# Altium schematic sheet Sheet4.SchDoc(Sheet4)
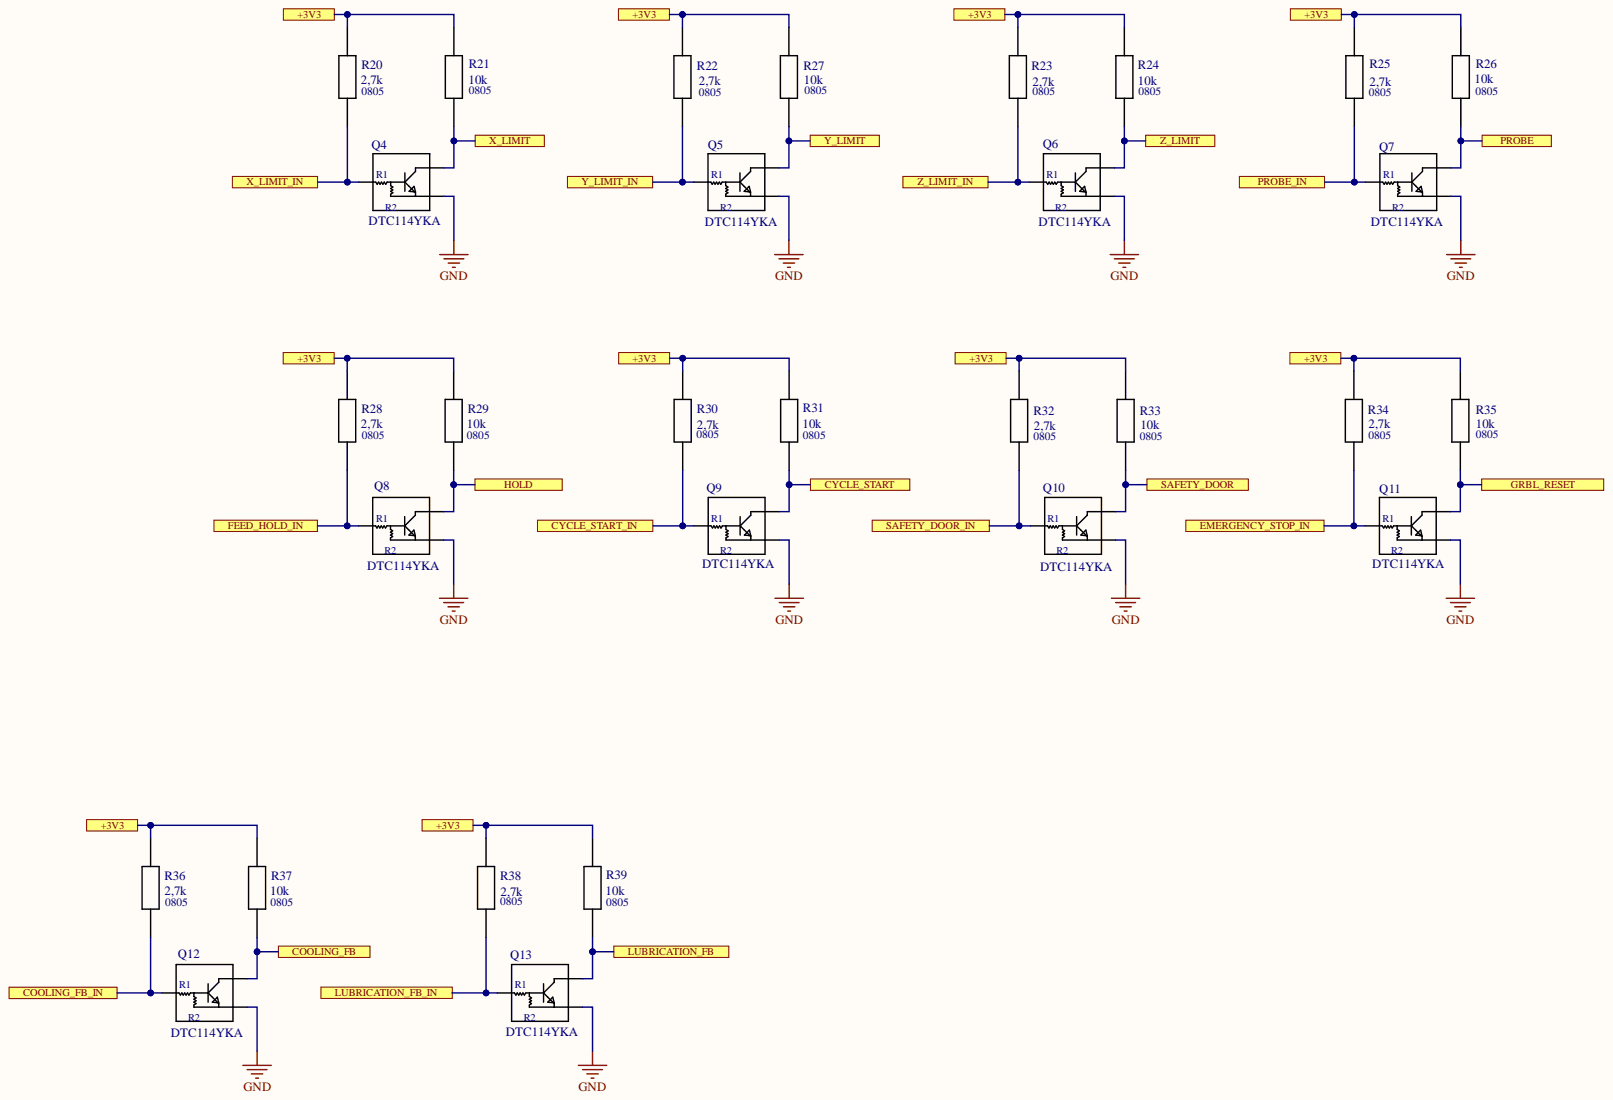
[diagram p0: Sheet4.SchDoc]

## Parts
Q4  DTC114YKA  [SOT-346 (SC59) Black]
Q5  DTC114YKA  [SOT-346 (SC59) Black]
Q6  DTC114YKA  [SOT-346 (SC59) Black]
Q7  DTC114YKA  [SOT-346 (SC59) Black]
Q8  DTC114YKA  [SOT-346 (SC59) Black]
Q9  DTC114YKA  [SOT-346 (SC59) Black]
Q10  DTC114YKA  [SOT-346 (SC59) Black]
Q11  DTC114YKA  [SOT-346 (SC59) Black]
Q12  DTC114YKA  [SOT-346 (SC59) Black]
Q13  DTC114YKA  [SOT-346 (SC59) Black]
R20  2,7k  Case: 0805  [SMD 0805]
R21  10k  Case: 0805  [SMD 0805]
R22  2,7k  Case: 0805  [SMD 0805]
R23  2,7k  Case: 0805  [SMD 0805]
R24  10k  Case: 0805  [SMD 0805]
R25  2,7k  Case: 0805  [SMD 0805]
R26  10k  Case: 0805  [SMD 0805]
R27  10k  Case: 0805  [SMD 0805]
R28  2,7k  Case: 0805  [SMD 0805]
R29  10k  Case: 0805  [SMD 0805]
R30  2,7k  Case: 0805  [SMD 0805]
R31  10k  Case: 0805  [SMD 0805]
R32  2,7k  Case: 0805  [SMD 0805]
R33  10k  Case: 0805  [SMD 0805]
R34  2,7k  Case: 0805  [SMD 0805]
R35  10k  Case: 0805  [SMD 0805]
R36  2,7k  Case: 0805  [SMD 0805]
R37  10k  Case: 0805  [SMD 0805]
R38  2,7k  Case: 0805  [SMD 0805]
R39  10k  Case: 0805  [SMD 0805]

## Ports
+3V3, COOLING_FB, COOLING_FB_IN, CYCLE_START, CYCLE_START_IN, EMERGENCY_STOP_IN, FEED_HOLD_IN, GRBL_RESET, HOLD, LUBRICATION_FB, LUBRICATION_FB_IN, PROBE, PROBE_IN, SAFETY_DOOR, SAFETY_DOOR_IN, X_LIMIT, X_LIMIT_IN, Y_LIMIT, Y_LIMIT_IN, Z_LIMIT, Z_LIMIT_IN

## Nets
GND: Q4.2 Q5.2 Q6.2 Q7.2 Q8.2 Q9.2 Q10.2 Q11.2 Q12.2 Q13.2
+3V3: R20.1 R21.1 R22.1 R23.1 R24.1 R25.1 R26.1 R27.1 R28.1 R29.1 R30.1 R31.1 R32.1 R33.1 R34.1 R35.1 R36.1 R37.1 R38.1 R39.1 ; port +3V3
COOLING_FB: Q12.3 R37.2 ; port COOLING_FB
COOLING_FB_IN: Q12.1 R36.2 ; port COOLING_FB_IN
CYCLE_START: Q9.3 R31.2 ; port CYCLE_START
CYCLE_START_IN: Q9.1 R30.2 ; port CYCLE_START_IN
EMERGENCY_STOP_IN: Q11.1 R34.2 ; port EMERGENCY_STOP_IN
FEED_HOLD_IN: Q8.1 R28.2 ; port FEED_HOLD_IN
GRBL_RESET: Q11.3 R35.2 ; port GRBL_RESET
HOLD: Q8.3 R29.2 ; port HOLD
LUBRICATION_FB: Q13.3 R39.2 ; port LUBRICATION_FB
LUBRICATION_FB_IN: Q13.1 R38.2 ; port LUBRICATION_FB_IN
PROBE: Q7.3 R26.2 ; port PROBE
PROBE_IN: Q7.1 R25.2 ; port PROBE_IN
SAFETY_DOOR: Q10.3 R33.2 ; port SAFETY_DOOR
SAFETY_DOOR_IN: Q10.1 R32.2 ; port SAFETY_DOOR_IN
X_LIMIT: Q4.3 R21.2 ; port X_LIMIT
X_LIMIT_IN: Q4.1 R20.2 ; port X_LIMIT_IN
Y_LIMIT: Q5.3 R27.2 ; port Y_LIMIT
Y_LIMIT_IN: Q5.1 R22.2 ; port Y_LIMIT_IN
Z_LIMIT: Q6.3 R24.2 ; port Z_LIMIT
Z_LIMIT_IN: Q6.1 R23.2 ; port Z_LIMIT_IN

## Library data of the parts
Q4-Q13 = DTC114YKA: NPN Digital 50V 100mA 200mW  R1=10kOm R2=47kOm; Manufacturer: ROHM Semiconductor; Case: SOT-346 (SC59); Component Kind: Standard; HalpURL: https://store.comet.bg/Catalogue/Product/23715/; Library Name: CAN_Library.IntLib; Value: DTC114YKA
R20-R39 = Resistor_1: RES; Component Kind: Standard; HelpURL: https://store.comet.bg/Catalogue/RES/Resistors/#eyJpcHAiOiIxMDAiLCJtIjoiIn0%3D; Library Name: CAN_Library.IntLib
  R20 R22 R23 R25 R28 R30 R32 R34 R36 R38: Value: 2,7k
  R21 R24 R26 R27 R29 R31 R33 R35 R37 R39: Value: 10k
